# Altium schematic sheet Power.SchDoc(Power)
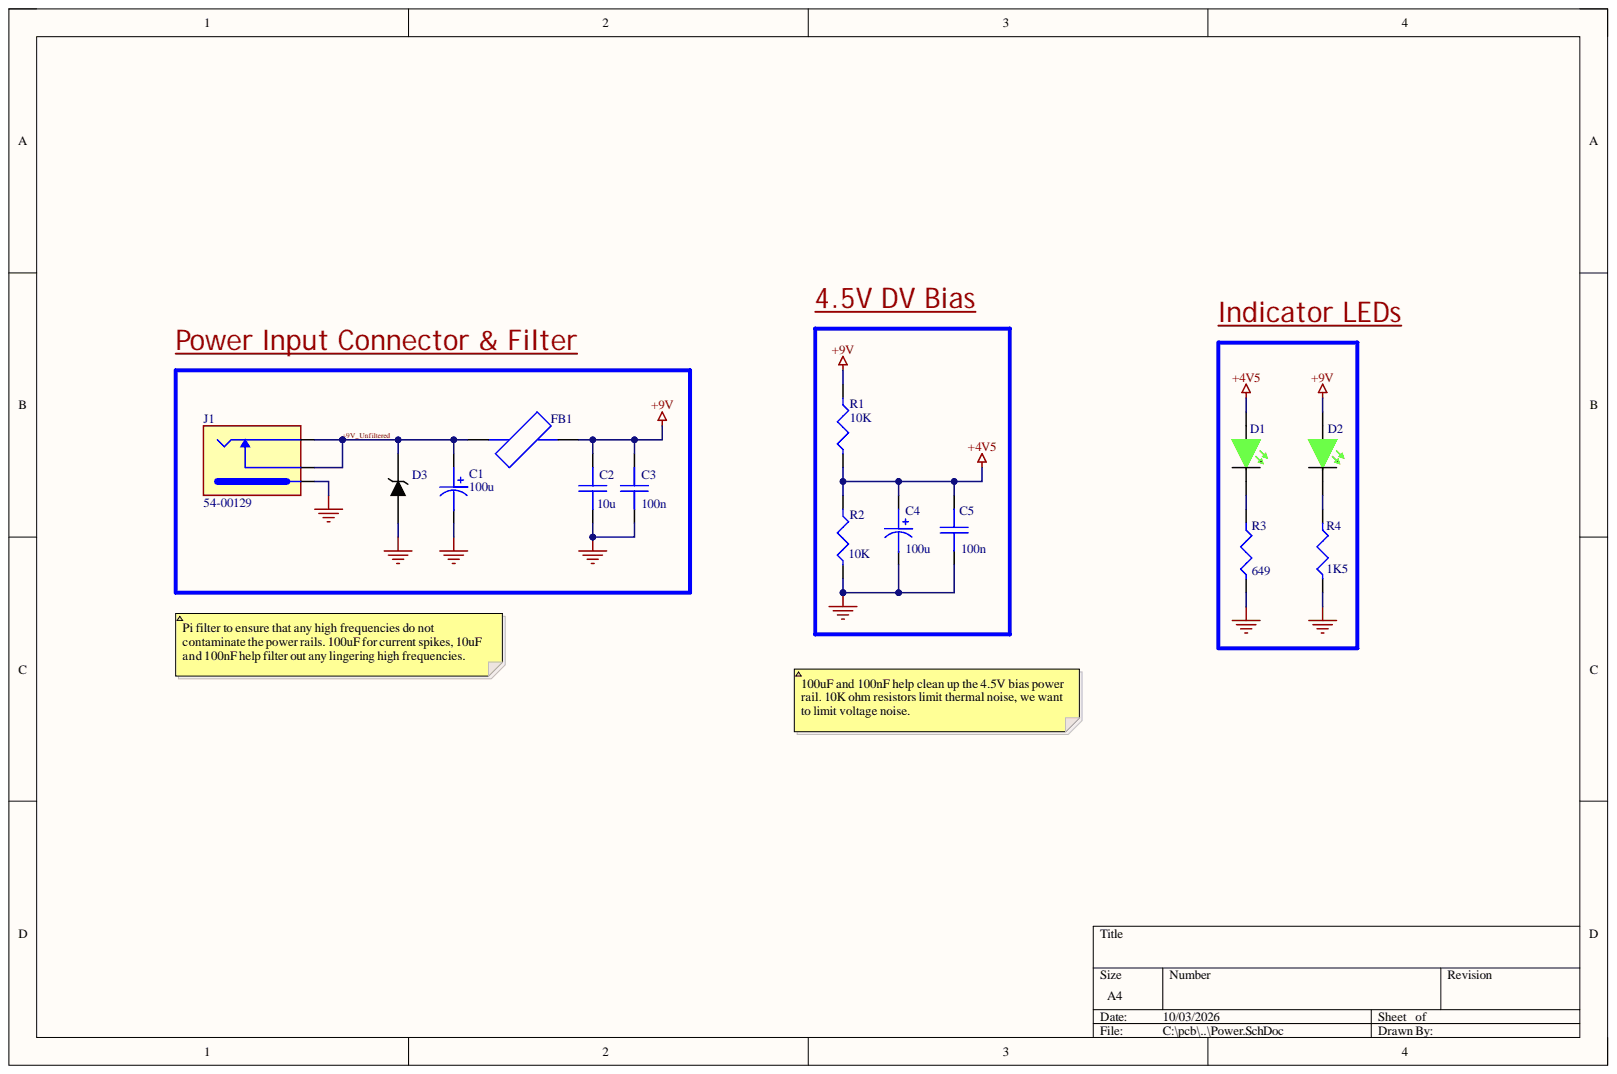
[diagram p0: Power.SchDoc]

## Parts
C1  100u  [ESL107M035AE3AA]
C2  10u  [C1206]
C3  100n  [C0805]
C4  100u  [ESL107M035AE3AA]
C5  100n  [C0805]
D1  WP1503GT  [WP1503GT]  (value not drawn)
D2  WP1503GT  [WP1503GT]  (value not drawn)
D3  SAC10-E3/54  [SAC10-E3/54]  (value not drawn)
FB1  MPZ2012S601AT000  [MPZ2012S601AT000]  (value not drawn)
J1  54-00129  [54-00129]
R1  10K  [R0805]
R2  10K  [R0805]
R3  649  [R0805]
R4  1K5  [R0805]

## Nets
+4V5: C4.1 C5.2 D1.1 R1.1 R2.2
+9V: C2.2 C3.2 D2.1 FB1.2 R1.2
GND: C1.2 C2.1 C3.1 C4.2 C5.1 D3.2 J1.1 R2.1 R3.1 R4.1
+9V_Unfiltered: C1.1 D3.1 FB1.1 J1.2 J1.3
NetD1_2: D1.2 R3.2
NetD2_2: D2.2 R4.2
